# Altium schematic sheet 02.Power_path.SchDoc
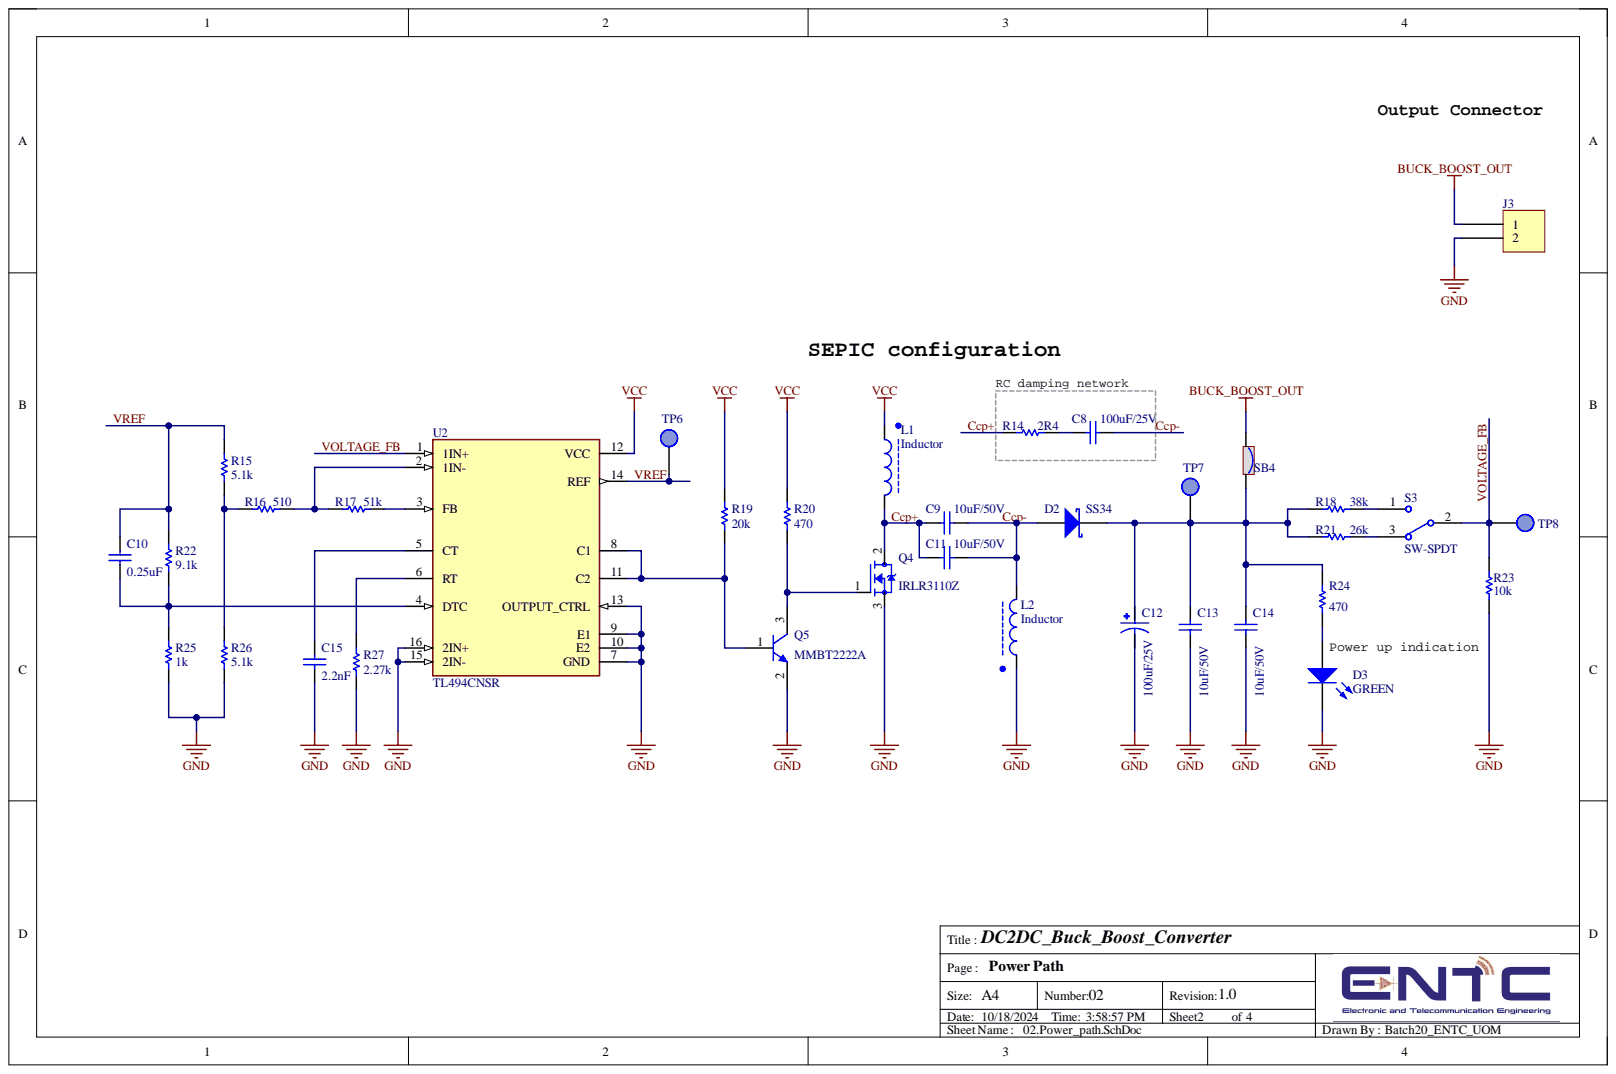
[diagram p0: 02.Power_path.SchDoc]

## Parts
C8  100uF/25V  [CAP1206]
C9  10uF/50V  [cap0805]
C10  0.25uF  [cap0805]
C11  10uF/50V  [cap0805]
C12  100uF/25V  [CAP_EEEFC0J680P]
C13  10uF/50V  [cap0805]
C14  10uF/50V  [cap0805]
C15  2.2nF  [cap0805]
D2  SS34  [DIO_SS34]
D3  GREEN  [LED0805]
J3  (no value)  [JST XH B2B-XH-A]
L1  Inductor  [toroid-16x8]
L2  Inductor  [toroid-16x8]
Q4  IRLR3110Z  [TO252]
Q5  MMBT2222A  [SOT23]
R14  2R4  [res1206]
R15  5.1k  [RES0805]
R16  510  [RES0805]
R17  51k  [RES0805]
R18  38k  [RES0805]
R19  20k  [RES0805]
R20  470  [res1206]
R21  26k  [RES0805]
R22  9.1k  [RES0805]
R23  10k  [RES0805]
R24  470  [RES0805]
R25  1k  [RES0805]
R26  5.1k  [RES0805]
R27  2.27k  [RES0805]
S3  SW-SPDT  [SS12D00G3]
SB4  SB_ON  [SOLDER-BRIDGE_R1210-0R]  (value not drawn)
TP6  (no value)  [tp]  (no BOM)
TP7  (no value)  [tp]  (no BOM)
TP8  (no value)  [tp]  (no BOM)
U2  TL494CNSR  [SOIC127P780X200-16N]

## Nets
BUCK_BOOST_OUT: J3.1 SB4.1
GND: C12.2 C13.2 C14.2 C15.2 D3.2 J3.2 L2.1 Q4.3 Q5.2 R23.1 R25.1 R26.1 R27.1 U2.7(GND) U2.9(E1) U2.10(E2) U2.13(OUTPUT_CTRL) U2.15(2IN-) U2.16(2IN+)
VCC: L1.1 R19.2 R20.2 U2.12(VCC)
Ccp+: C9.2 C11.2 L1.2 Q4.2 R14.2
Ccp-: C8.1 C9.1 C11.1 D2.1 L2.2
VOLTAGE_FB: R23.2 S3.2 TP8.1 U2.1(1IN+)
VREF: C10.1 R15.2 R22.2 TP6.1 U2.14(REF)
NetC8_2: C8.2 R14.1
NetC10_2: C10.2 R22.1 R25.2 U2.4(DTC)
NetC12_1: C12.1 C13.1 C14.1 D2.2 R18.2 R21.2 R24.2 SB4.2 TP7.1
NetC15_1: C15.1 U2.5(CT)
NetD3_1: D3.1 R24.1
NetQ4_1: Q4.1 Q5.3 R20.1
NetQ5_1: Q5.1 R19.1 U2.8(C1) U2.11(C2)
NetR15_1: R15.1 R16.2 R26.2
NetR16_1: R16.1 R17.2 U2.2(1IN-)
NetR17_1: R17.1 U2.3(FB)
NetR18_1: R18.1 S3.1
NetR21_1: R21.1 S3.3
NetR27_2: R27.2 U2.6(RT)

## Library data of the parts
C8-C11 C13-C15 = Cap: Capacitor; Component Kind: Standard; Library Name: Altium_Main_Library.SchLib
C12 = Cap Pol: Polarized capacitor; Component Kind: Standard; Library Name: Altium_Main_Library.SchLib
D2 = D Schottky: Schottky Diode; Component Kind: Standard; Library Name: DC2DC_Buck_Boost_Converter_SchLib.SchLib
D3 = LED: SMD LED 0805 green; Component Kind: Standard; Library Name: Altium_Main_Library.SchLib
J3 = NC6-P108-02: CONN BARRIER STRIP 2CIRC 0.375"; Component Kind: Standard; Library Name: Altium_Main_Library.SchLib
L1 L2 = Inductor-ferrite core: Component Kind: Standard; Description: Inductor; Library Name: DC2DC_Buck_Boost_Converter_SchLib.SchLib
Q4 = IRLR3110Z: IRLR3110Z N-channel Power MOSFET; MP: IRFZ44N; Component Kind: Standard; Library Name: DC2DC_Buck_Boost_Converter_SchLib.SchLib
Q5 = NPN: NPN Bipolar Transistor; Component Kind: Standard; Library Name: Altium_Main_Library.SchLib
R14-R23 R25-R27 = Res: Resistor; Component Kind: Standard; Library Name: Altium_Main_Library.SchLib
R24 = Res: Resistor 0805; Component Kind: Standard; Library Name: Altium_Main_Library.SchLib
S3 = SW-SPDT: SPDT switch; Component Kind: Standard; Library Name: DC2DC_Buck_Boost_Converter_SchLib.SchLib
SB4 = SB_ON: Solder Bridge ON; MP: PMR03EZPJ000; Component Kind: Standard; Library Name: Altium_Main_Library.SchLib
TP6-TP8 = TP: Test Point; Library Name: Altium_Main_Library.SchLib
U2 = TL494CNSR: 40V, 0.2A 300KHz PWM ì»¨íŠ¸ë¡¤ëŸ¬; Component Kind: Standard; Library Name: DC2DC_Buck_Boost_Converter_SchLib.SchLib; MP: TL494CNSR
